# Altium schematic sheet MCU.SchDoc(MCU)
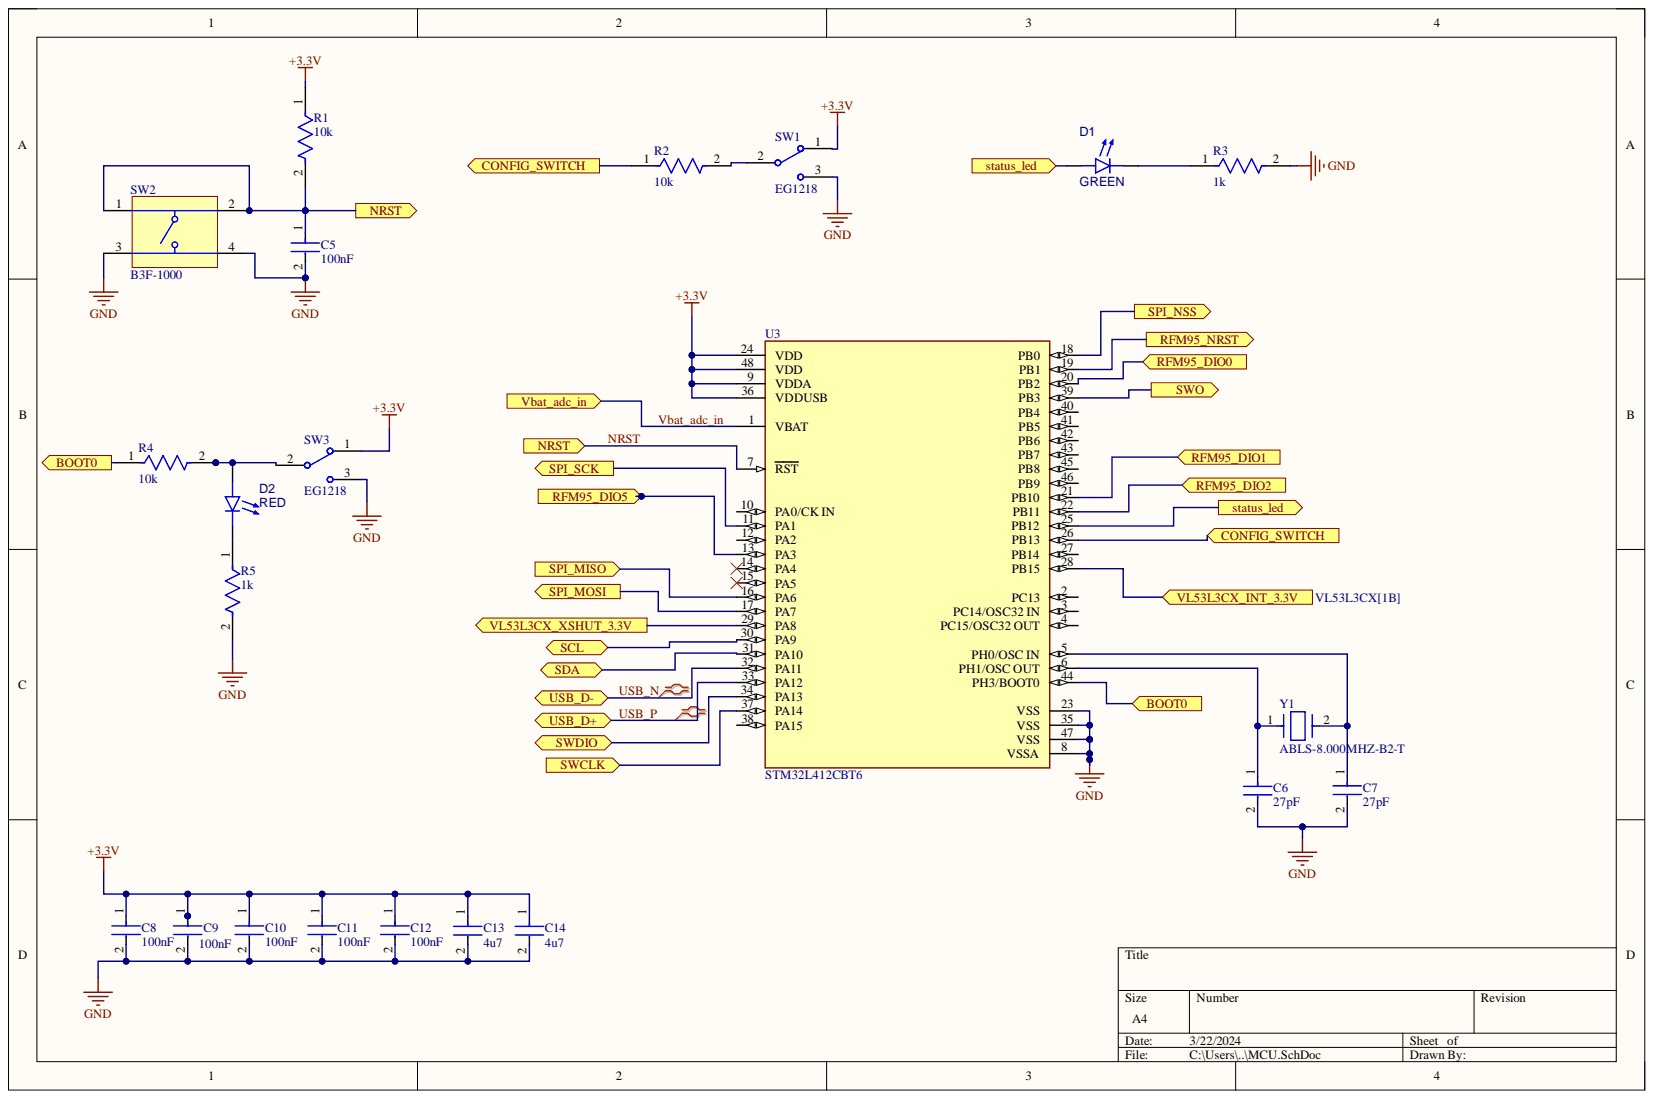
[diagram p0: MCU.SchDoc]

## Parts
C5  100nF  [FP-C1206C-EB-MFG]
C6  27pF  [FP-GCM31A-0_2-MFG]
C7  27pF  [FP-GCM31A-0_2-MFG]
C8  100nF  [FP-C1206C-EB-MFG]
C9  100nF  [FP-C1206C-EB-MFG]
C10  100nF  [FP-C1206C-EB-MFG]
C11  100nF  [FP-C1206C-EB-MFG]
C12  100nF  [FP-C1206C-EB-MFG]
C13  4u7  [FP-GRM21B-0_15-MFG]
C14  4u7  [FP-GRM21B-0_15-MFG]
D1  GREEN  [156120VS75000-Footprint-1]
D2  RED  [156120RS75000-Footprint-1]
R1  10k  [FP-RMCF1206-IPC_C]
R2  10k  [FP-RMCF1206-IPC_C]
R3  1k  [FP-RMCF1206-MFG]
R4  10k  [FP-RMCF1206-IPC_C]
R5  1k  [FP-RMCF1206-MFG]
SW1  EG1218  [ESWI-EG1218_V]
SW2  B3F-1000  [OMRON-B3F-1000-4_V]
SW3  EG1218  [ESWI-EG1218_V]
U3  STM32L412CBT6  [FP-LQFP48-5B-IPC_A]
Y1  ABLS-8.000MHZ-B2-T  [FP-ABLS-MFG]

## Ports
BOOT0, CONFIG_SWITCH, NRST, RFM95_DIO0, RFM95_DIO1, RFM95_DIO2, RFM95_DIO5, RFM95_NRST, SCL, SDA, SPI_MISO, SPI_MOSI, SPI_NSS, SPI_SCK, status_led, SWCLK, SWDIO, SWO, USB_D+, USB_D-, Vbat_adc_in, VL53L3CX_INT_3.3V, VL53L3CX_XSHUT_3.3V

## Nets
+3.3V: C8.1 C9.1 C10.1 C11.1 C12.1 C13.1 C14.1 R1.1 SW1.1 SW3.1 U3.9(VDDA) U3.24(VDD) U3.36(VDDUSB) U3.48(VDD)
GND: C5.2 C6.2 C7.2 C8.2 C9.2 C10.2 C11.2 C12.2 C13.2 C14.2 R3.2 R5.2 SW1.3 SW2.3 SW2.4 SW3.3 U3.8(VSSA) U3.23(VSS) U3.35(VSS) U3.47(VSS)
BOOT0: R4.1 U3.44(PH3/BOOT0) ; port BOOT0
CONFIG_SWITCH: R2.1 U3.26(PB13) ; port CONFIG_SWITCH
NRST: C5.1 R1.2 SW2.1 SW2.2 U3.7(RST) ; port NRST
RFM95_DIO0: U3.20(PB2) ; port RFM95_DIO0
RFM95_DIO1: U3.21(PB10) ; port RFM95_DIO1
RFM95_DIO2: U3.22(PB11) ; port RFM95_DIO2
RFM95_DIO5: U3.13(PA3) ; port RFM95_DIO5
RFM95_NRST: U3.19(PB1) ; port RFM95_NRST
SCL: U3.30(PA9) ; port SCL
SDA: U3.31(PA10) ; port SDA
SPI_MISO: U3.16(PA6) ; port SPI_MISO
SPI_MOSI: U3.17(PA7) ; port SPI_MOSI
SPI_NSS: U3.18(PB0) ; port SPI_NSS
SPI_SCK: U3.11(PA1) ; port SPI_SCK
status_led: D1.2 U3.25(PB12) ; port status_led
SWCLK: U3.37(PA14) ; port SWCLK
SWDIO: U3.34(PA13) ; port SWDIO
SWO: U3.39(PB3) ; port SWO
USB_N: U3.32(PA11)
USB_P: U3.33(PA12)
Vbat_adc_in: U3.1(VBAT) ; port Vbat_adc_in
VL53L3CX_INT_3.3V: U3.28(PB15) ; port VL53L3CX_INT_3.3V
VL53L3CX_XSHUT_3.3V: U3.29(PA8) ; port VL53L3CX_XSHUT_3.3V
NetC6_1: C6.1 U3.6(PH1/OSC_OUT) Y1.1
NetC7_1: C7.1 U3.5(PH0/OSC_IN) Y1.2
NetD1_1: D1.1 R3.1
NetD2_1: D2.1 R5.1
NetD2_2: D2.2 R4.2 SW3.2
NetR2_2: R2.2 SW1.2

Not connected: U3 2(PC13) 3(PC14/OSC32_IN) 4(PC15/OSC32_OUT) 10(PA0/CK_IN) 12(PA2) 14(PA4) 15(PA5) 27(PB14) 38(PA15) 40(PB4) 41(PB5) 42(PB6) 43(PB7) 45(PB8) 46(PB9)

## Library data of the parts
C5 C8-C12 = CMP-03020-021070-1: CAP CER 0.1UF 16V 10% X7R 1206; Manufacturer: KEMET; Manufacturer Part Number: C1206C104K4RACTU; Automotive Grade: Grade 0; Capacitance: 0.10 μF; Material: Ceramic; Temperature Coefficient: 15%; Tolerance: 0.1; Value: 0.10 μF; Automotive: Yes; Category: Cap; Component Kind: Standard; ComponentLink2Description: Datasheet; ComponentLink2URL: https://content.kemet.com/datasheets/KEM_C1007_X8R_ULTRA_150C_SMD.pdf; Device Class L1: Passive Components; Device Class L2: Capacitors; Device Class L3: Ceramic Capacitors; Digikey Description: CAP CER 0.1UF 16V 10% X7R 1206; Height: 0.88mm; Ipc Land Pattern Name: CAPC320160X78; Lead Free: Yes; Library Name: Altium Content Vault; Mouser Description: Multilayer Ceramic Capacitors MLCC - SMD/SMT 16volts 0.1uF X7R 10%; Octopart: https://octopart.com/c1206c104k4ractu-kemet-12577600; Package: C1206C-EB; RoHS: TRUE; Temperature: -55°C to +150°C; Temperature Characteristic: X7R; Temperature Range High: +150°C; Temperature Range Low: -55°C; Voltage Rating: 16 V
C6 C7 = CMP-06035-011656-1: Ceramic Capacitor for Automotive 27pF ±1% 1kVDC C0G 1206 Paper T/R; Component Kind: Standard; Library Name: Altium Content Vault
C13 C14 = CMP-06035-005997-1: Chip Multilayer Ceramic Capacitors for General Purpose, 0805, 4.7uF, X7R, 15%, 10%, 10V; Capacitance: 4.7uF; Case/Package: 0805; Component Kind: Standard; Dielectric: X7R; Length: 2mm; Library Name: Altium Content Vault; Thickness: 1.25mm; Tolerance: 10%; Voltage Rating (DC): 10V; Width: 1.25mm
D1 = CMP-007-00036-1: Single Color LED; Component Kind: Standard; RoHS Compliant: Yes; Library Name: tutorial_PCB
D2 = CMP-007-00037-1: Single Color LED; Component Kind: Standard; RoHS Compliant: Yes; Library Name: tutorial_PCB
R1 R2 R4 = CMP-26527-000061-1: 10kΩ ±5% 0.25W 1206 Thick Film Chip Resistor AEC-Q200 compliant; Max Power Dissipation: 250mW; Resistance: 10kR; Temperature Coefficient: 100ppm/°C; Case Code (Imperial): 1206; Case Code (Metric): 3216; Case/Package: 1206; Component Kind: Standard; Construction: Rectangular; Contact Plating: Tin; Depth: 1.6mm; Features: Automotive AEC-Q200; Height: 0.028inch; Lead Free: Lead Free; Length: 3.2mm; Library Name: Altium Content Vault; Max Operating Temperature: 155°C; Military Standard: Not; Min Operating Temperature: -55°C; Mount: Surface Mount; Number of Terminations: 2; Packaging: Tape and Reel; Power Rating: 250mW; Radiation Hardening: No; Resistor Type: Thick Film; RoHS Compliant: Yes; Series: RMCF; Size Code: 1206; Tolerance: 5%; Width: 0.063inch
R3 R5 = CMP-26527-000044-1: 1kΩ ±5% 0.25W 1206 Thick Film Chip Resistor AEC-Q200 compliant; Max Power Dissipation: 250mW; Resistance: 1kR; Temperature Coefficient: 200ppm/°C; Working Voltage: 200V; Case Code (Imperial): 1206; Case Code (Metric): 3216; Case/Package: 1206; Component Kind: Standard; Construction: Rectangular; Contact Plating: Tin; Depth: 1.6mm; Features: Automotive AEC-Q200; Height: 0.028inch; Lead Free: Lead Free; Length: 3.2mm; Library Name: Altium Content Vault; Max Operating Temperature: 155°C; Military Standard: Not; Min Operating Temperature: -55°C; Mount: Surface Mount; Number of Terminations: 2; Packaging: Tape and Reel; Power Rating: 250mW; Radiation Hardening: No; Resistor Type: Thick Film; RoHS Compliant: Yes; Series: RMCF; Tolerance: 5%; Width: 0.063inch
SW1 SW3 = CMP-011-00006-2: Slide Switch, SPDT, 500 V, -20 to 70 degC, 3-Pin THD, RoHS, Bulk; Manufacturer: E-Switch; Contact Current Rating: 200mA; Operating Force: 250gf; Voltage Rating (AC): 30V; Case/Package: EG1218; Component Kind: Standard; Contact Finish: Silver; Contact Material: Copper; Contact Plating: Silver; Current Rating: 200mA; Datasheet URL: https://www.e-switch.com/system/asset/product_line/data_sheet/119/EG.pdf; Datasheet Version: Oct-15; Manufacturer URL: http://www.e-switch.ch/en/; Max Operating Temperature: 70°C; Min Operating Temperature: -20°C; Mounting Technology: TH; Package Description: 3-Pin Through Hole Device, Body 11.6 x 4 mm, Pitch 2.5 mm; Package Quantity: 1000; Package Reference: EG1218; Packaging: Bulk; Pins: 3; RoHS Compliant: True; Throw Configuration: SPDT; Voltage Rating (DC): 30V; Library Name: tutorial_PCB
SW2 = CMP-011-00004-2: Tactile Switch, SPST-NO, 0.05 A, 24 V, -25 to 70 degC, 4-Pin THD, RoHS, Bulk; Manufacturer: Omron Electronic Components; Contact Current Rating: 50mA; Max Contact Resistance: 100mohm; Max Voltage Rating (DC): 24V; Operating Force: 100gf; Resistance: 0.1Ohm; Throw Configuration: SPST; Case/Package: B3F-1000; Component Kind: Standard; Contact Material: Gold; Contact Plating: Gold; Current Rating: 50mA; Datasheet URL: http://www.omron.com/ecb/products/pdf/en-b3f.pdf; Datasheet Version: Jan-15; Manufacturer URL: http://www.omron.com/; Max Current Rating: 50mA; Max Operating Temperature: 70°C; Min Operating Temperature: -25°C; Mounting Technology: TH; Package Description: 4-Pin Through Hole Device, Body 6 x 6 mm; Package Quantity: 1500; Package Reference: B3F-1000; Packaging: Bulk; Pins: 4; RoHS Compliant: True; Voltage Rating: 24V; Voltage Rating (DC): 24V; Library Name: tutorial_PCB
U3 = CMP-12105-000110-1: IC MCU 32BIT 128KB FLASH 48LQFP; Component Kind: Standard; Library Name: Altium Content Vault; RoHS Compliant: Yes
Y1 = CMP-27762-009874-1: CRYSTAL 8.0000MHZ 18PF SMD; Accuracy: 20ppm; ESR (Equivalent Series Resistance): 80R; Frequency: 8MHz; Frequency Stability: 50ppm; Operating Frequency: 8 MHz; Case/Package: SMD/SMT; Component Kind: Standard; Contact Plating: Tin; Depth: 4.7mm; Frequency Tolerance: 20ppm; Height: 0.165inch; Lead Free: Lead Free; Length: 11.4mm; Library Name: Altium Content Vault; Load Capacitance: 18pF; Max Operating Temperature: 70°C; Min Operating Temperature: -20°C; Mount: Surface Mount; Number of Pins: 2; Package Quantity: 1000; Packaging: Tape and Reel; Radiation Hardening: No; REACH SVHC: No SVHC; Series: ABLS; Series Resistance: 80R; Termination: SMD/SMT; Tolerance: 20ppm; Width: 4.7mm
